# Altium schematic sheet CW551_MainBoard_LCDConnector.SchDoc
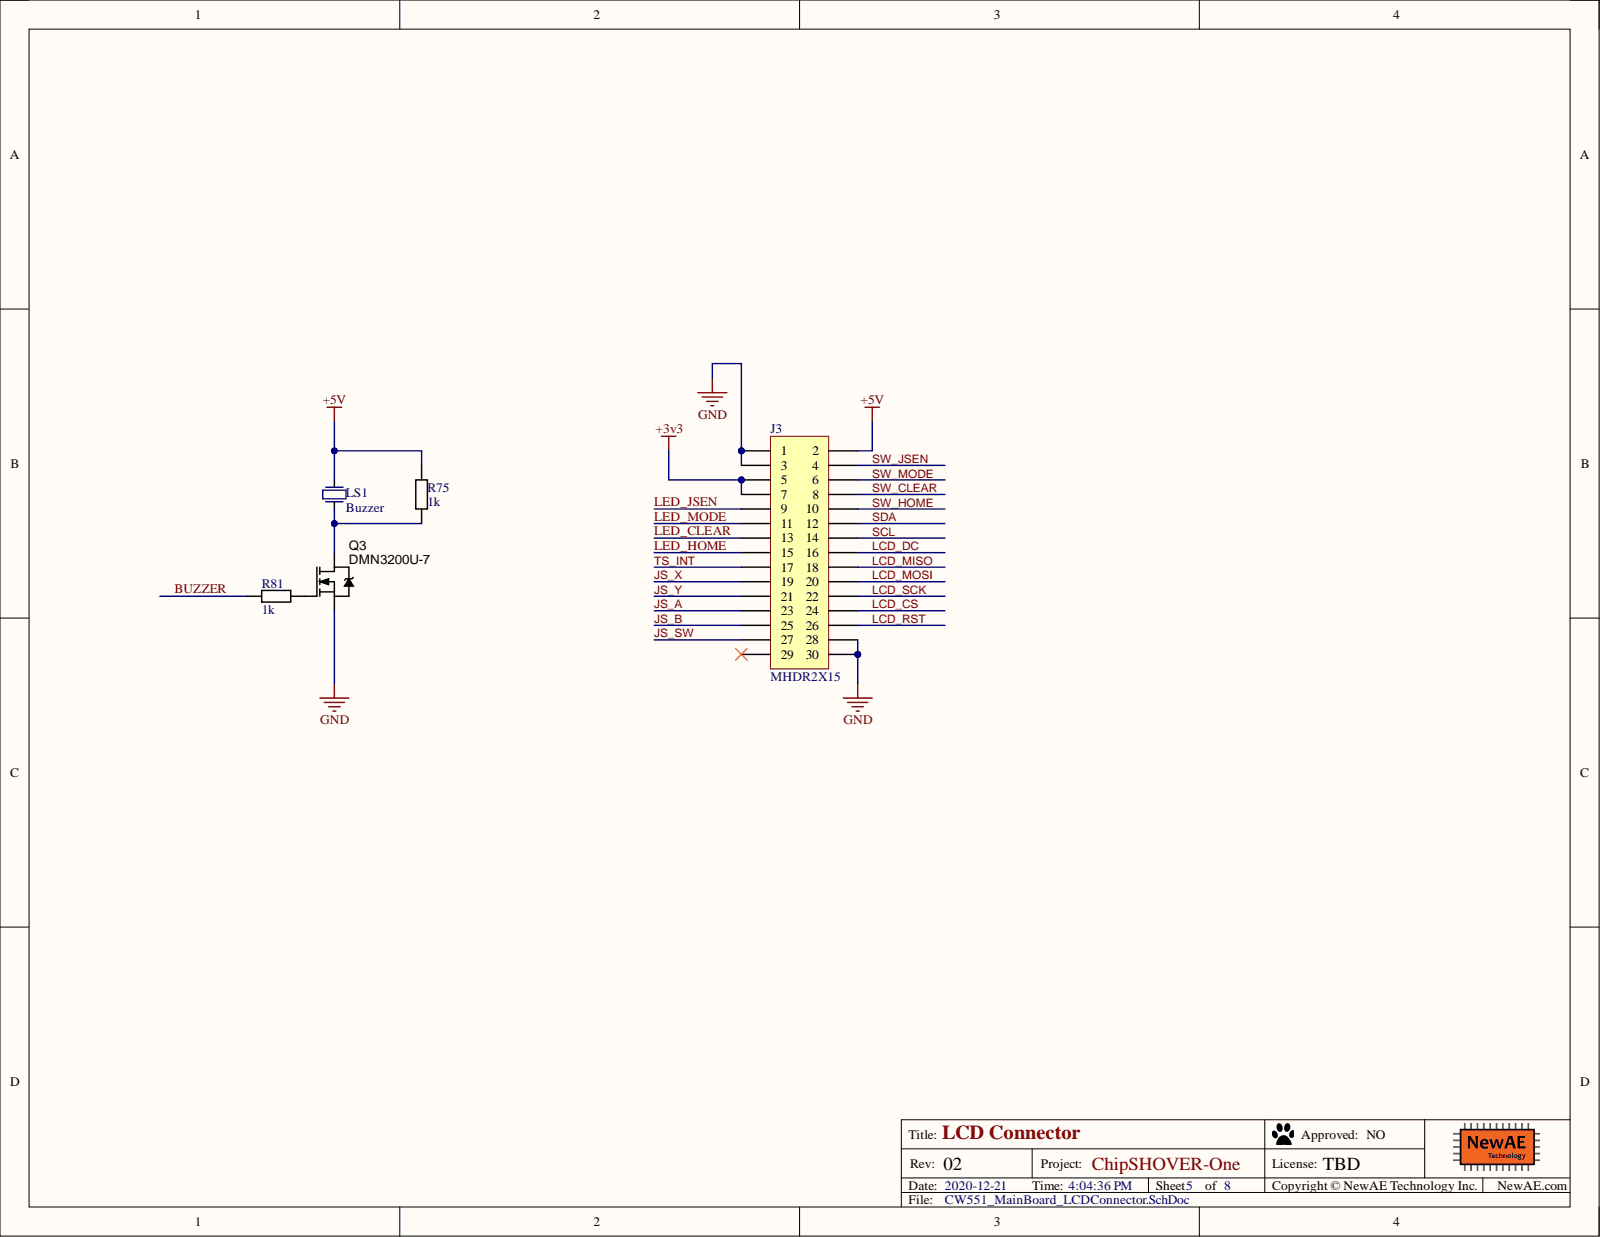
[diagram p0: CW551_MainBoard_LCDConnector.SchDoc]

## Parts
J3  MHDR2X15  [MHDR2X15]
LS1  Buzzer  [5mm_Radial]
Q3  DMN3200U-7  [SOT23]
R75  1k  [0603]
R81  1k  [0603]

## Nets
+3v3: J3.5 J3.7
+5V: J3.2 LS1.1 R75.2
GND: J3.1 J3.3 J3.28 J3.30 Q3.2
BUZZER: R81.1
JS_A: J3.23
JS_B: J3.25
JS_SW: J3.27
JS_X: J3.19
JS_Y: J3.21
LCD_CS: J3.24
LCD_DC: J3.16
LCD_MISO: J3.18
LCD_MOSI: J3.20
LCD_RST: J3.26
LCD_SCK: J3.22
LED_CLEAR: J3.13
LED_HOME: J3.15
LED_JSEN: J3.9
LED_MODE: J3.11
SCL: J3.14
SDA: J3.12
SW_CLEAR: J3.8
SW_HOME: J3.10
SW_JSEN: J3.4
SW_MODE: J3.6
TS_INT: J3.17
NetLS1_2: LS1.2 Q3.3 R75.1
NetQ3_1: Q3.1 R81.2

Not connected: J3 29

## Library data of the parts
J3 = MHDR2X15: Header, 15-Pin, Dual row; Component Kind: Standard; LatestRevisionDate: 17-Jul-2002; LatestRevisionNote: Re-released for DXP Platform.; Library Name: Miscellaneous Connectors.IntLib; Signal Integrity: Connector; Publisher: Altium Limited
LS1 = PS1240P02BT: AUDIO PIEZO TRANSDUCER 30V TH; Manufacture 1: TDK Corporation; Component Group: Crystal; Database Table Name: main; PP Material Stack: 0; Component Kind: Standard; Component Type: Standard; Library Name: NewAE_AltiumLib.DBLib; Manufacture Part Number 1: PS1240P02BT; Mounting Technology: Through-hole; Part Number: PS1240P02BT; Pin Count: 2; PP Rotation: 0; RoHS: Yes; Supplier 1: Digi-Key; Supplier Part Number 1: 445-2525-1-ND
Q3 = DMN3200U-7: MOSFET N-CH 30V 2.2A SOT23-3; Manufacture 1: Diodes Inc.; Database Table Name: main; PP Material Stack: 0; Rated Power: 3A; Component Group: MOSFET; Component Kind: Standard; Component Type: Standard; LCSC Equivalent: C461018; Library Name: NewAE_AltiumLib.DBLib; Manufacture Part Number 1: DMN3200U-7; Mounting Technology: SMT; Part Number: DMN3200U-7; Pin Count: 3; PP Rotation: 0; Rated Voltage: 30V; RoHS: Yes; Supplier 1: Digi-Key; Supplier Part Number 1: DMN3200U-7DICT-ND
R75 R81 = RCHIP-1K-0603: 1k res, 0603, 1%, 1/10W; Manufacture 1: Yageo; Manufacture Part Number 1: RC0603FR-071KL; Case-Metric: 1608; Component Group: Resistor; Database Table Name: main; Rated Power: 0.1W; Rated Voltage: 75VDC; Case-EIA: 0603; Component Kind: Standard; Component Type: Standard; Library Name: NewAE_AltiumLib.DBLib; Mounting Technology: SMT; Part Number: RCHIP-1K-0603; Pin Count: 2; Supplier 1: Digi-Key; Supplier Part Number 1: 311-1.00KHRCT-ND; Temperature Range: -55C to 155C; Tolerance: 1%; Value: 1k
